# Altium schematic sheet 01.W5100S.SchDoc
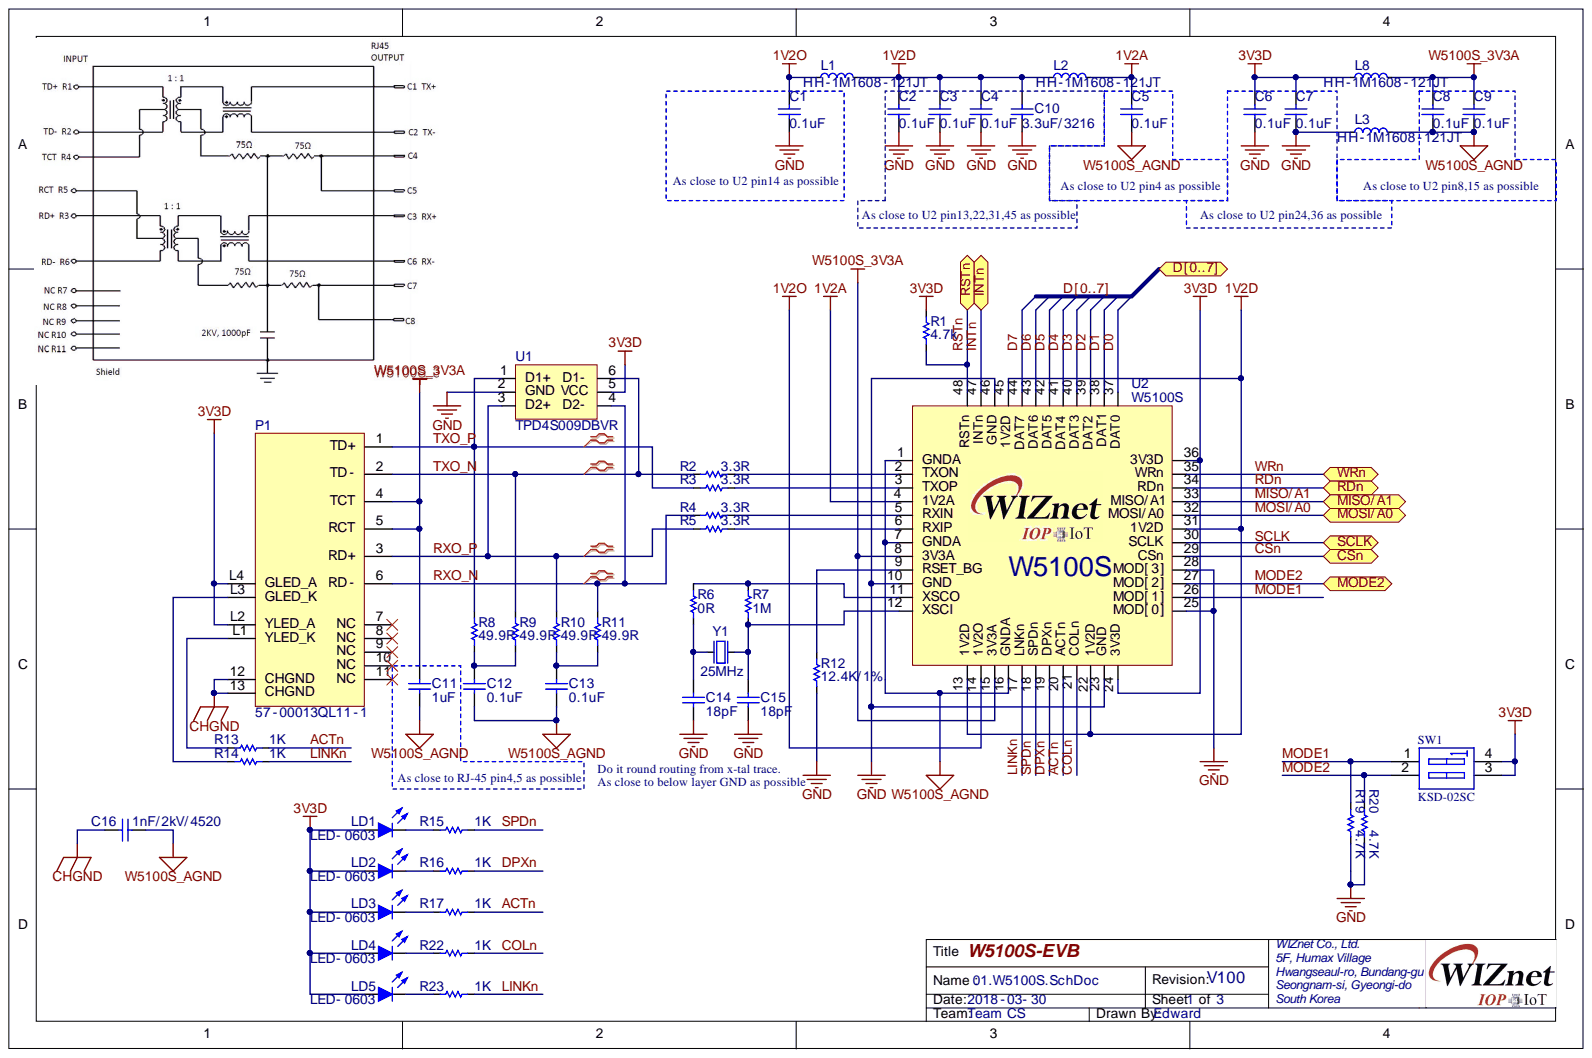
[diagram p0: 01.W5100S.SchDoc]

## Parts
C1  0.1uF  [CAP-0603]
C2  0.1uF  [CAP-0603]
C3  0.1uF  [CAP-0603]
C4  0.1uF  [CAP-0603]
C5  0.1uF  [CAP-0603]
C6  0.1uF  [CAP-0603]
C7  0.1uF  [CAP-0603]
C8  0.1uF  [CAP-0603]
C9  0.1uF  [CAP-0603]
C10  3.3uF/3216  [CAP-1206]
C11  1uF  [CAP-0603]
C12  0.1uF  [CAP-0603]
C13  0.1uF  [CAP-0603]
C14  18pF  [CAP-0603]
C15  18pF  [CAP-0603]
C16  1nF/2kV/4520  [CAP-1808]
L1  HH-1M1608-121JT  [IND-0603]
L2  HH-1M1608-121JT  [IND-0603]
L3  HH-1M1608-121JT  [IND-0603]
L8  HH-1M1608-121JT  [IND-0603]
LD1  LED-0603  [LED-0603-RED]
LD2  LED-0603  [LED-0603-RED]
LD3  LED-0603  [LED-0603-RED]
LD4  LED-0603  [LED-0603-RED]
LD5  LED-0603  [LED-0603-RED]
P1  57-00013QL11-1  [RJ45-57-00013QL11-1]
R1  4.7k  [RES-0603]
R2  3.3R  [RES-0603]
R3  3.3R  [RES-0603]
R4  3.3R  [RES-0603]
R5  3.3R  [RES-0603]
R6  0R  [RES-0603]
R7  1M  [RES-0603]
R8  49.9R  [RES-0603]
R9  49.9R  [RES-0603]
R10  49.9R  [RES-0603]
R11  49.9R  [RES-0603]
R12  12.4K/1%  [RES-0603]
R13  1K  [RES-0603]
R14  1K  [RES-0603]
R15  1K  [RES-0603]
R16  1K  [RES-0603]
R17  1K  [RES-0603]
R19  4.7K  [RES-0603]
R20  4.7K  [RES-0603]
R22  1K  [RES-0603]
R23  1K  [RES-0603]
SW1  KSD-02SC  [KSD-02SC]
U1  TPD4S009DBVR  [SOT-23-6L]
U2  W5100S  [LQFP48(0.5/9.0)]
Y1  25MHz  [OSC-82J0626]

## Ports
CSN, D0, D1, D2, D3, D4, D5, D6, D7, D[0..7], INTN, MISO/A1, MODE2, MOSI/A0, RDN, RSTN, SCLK, WRN

## Nets
1V2A: C5.2 L2.2 U2.4(1V2A)
1V2D: C2.2 C3.2 C4.2 C10.2 L1.2 L2.1 U2.13(1V2D) U2.22(1V2D) U2.31(1V2D) U2.45(1V2D)
1V2O: C1.2 L1.1 U2.14(1V2O)
3V3D: C6.2 C7.2 L8.1 LD1.1 LD2.1 LD3.1 LD4.1 LD5.1 P1.L2(YLED_A) P1.L4(GLED_A) R1.2 SW1.3 SW1.4 U1.5(VCC) U2.24(3V3D) U2.36(3V3D)
CHGND: C16.1 P1.12(CHGND) P1.13(CHGND)
GND: C1.1 C2.1 C3.1 C4.1 C6.1 C7.1 C10.1 C14.1 C15.1 L3.1 R12.1 R19.1 R20.1 U1.2(GND) U2.10(GND) U2.23(GND) U2.25(MOD[_0_]) U2.28(MOD[_3_]) U2.46(GND) Y1.2 Y1.4
W5100S_3V3A: C8.2 C9.2 C11.2 L8.2 P1.4(TCT) P1.5(RCT) U2.8(3V3A) U2.15(3V3A)
W5100S_AGND: C5.1 C8.1 C9.1 C11.1 C12.1 C13.1 C16.2 L3.2 U2.1(GNDA) U2.7(GNDA) U2.16(GNDA)
ACTN: R13.1 R17.1 U2.20(ACTn)
COLN: R22.1 U2.21(COLn)
CSN: U2.29(CSn) ; port CSN
D0: U2.37(DAT0) ; port D[0..7]  [also labelled D]
D1: U2.38(DAT1) ; port D[0..7]  [also labelled D]
D2: U2.39(DAT2) ; port D[0..7]  [also labelled D]
D3: U2.40(DAT3) ; port D[0..7]  [also labelled D]
D4: U2.41(DAT4) ; port D[0..7]  [also labelled D]
D5: U2.42(DAT5) ; port D[0..7]  [also labelled D]
D6: U2.43(DAT6) ; port D[0..7]  [also labelled D]
D7: U2.44(DAT7) ; port D[0..7]  [also labelled D]
DPXN: R16.1 U2.19(DPXn)
INTN: U2.47(INTn) ; port INTN
LINKN: R14.1 R23.1 U2.17(LNKn)
MISO/A1: U2.33(MISO/_A1) ; port MISO/A1
MODE1: R19.2 SW1.1 U2.26(MOD[_1_])
MODE2: R20.2 SW1.2 U2.27(MOD[_2_]) ; port MODE2
MOSI/A0: U2.32(MOSI/_A0) ; port MOSI/A0
RDN: U2.34(RDn) ; port RDN
RSTN: R1.1 U2.48(RSTn) ; port RSTN
RXO_N: P1.6(RD_-) R4.2 R11.2 U1.4(D2-)
RXO_P: P1.3(RD+) R5.2 R10.2 U1.3(D2+)
SCLK: U2.30(SCLK) ; port SCLK
SPDN: R15.1 U2.18(SPDn)
TXO_N: P1.2(TD_-) R2.2 R9.2 U1.6(D1-)
TXO_P: P1.1(TD+) R3.2 R8.2 U1.1(D1+)
WRN: U2.35(WRn) ; port WRN
NetC12_2: C12.2 R8.1 R9.1
NetC13_2: C13.2 R10.1 R11.1
NetC14_2: C14.2 R6.1 Y1.1
NetC15_2: C15.2 R7.1 U2.12(XSCI) Y1.3
NetLD1_2: LD1.2 R15.2
NetLD2_2: LD2.2 R16.2
NetLD3_2: LD3.2 R17.2
NetLD4_2: LD4.2 R22.2
NetLD5_2: LD5.2 R23.2
NetP1_L1: P1.L1(YLED_K) R13.2
NetP1_L3: P1.L3(GLED_K) R14.2
NetR2_1: R2.1 U2.2(TXON)
NetR3_1: R3.1 U2.3(TXOP)
NetR4_1: R4.1 U2.5(RXIN)
NetR5_1: R5.1 U2.6(RXIP)
NetR6_2: R6.2 R7.2 U2.11(XSCO)
NetR12_2: R12.2 U2.9(RSET_BG)

Not connected: P1 7(NC) 8(NC) 9(NC) 10(NC) 11(NC)

## Library data of the parts
C1-C9 C11-C15 = CAP-0603: Ceramic CAP 0603(1608); Component Kind: Standard; Library Name: Scott_lib.IntLib
C10 = CAP-1206: Ceramic CAP 1206(3216); Component Kind: Standard; Library Name: Scott_lib.IntLib
C16 = CAP-1808: Ceramic CAP 1808(4520); Component Kind: Standard; Library Name: Scott_lib.IntLib
L1-L3 L8 = IND-0603: Inductor 0603(1608); Component Kind: Standard; Library Name: Scott_lib.IntLib
R1-R17 R19 R20 R22 R23 = RES-0603: RES0603; Component Kind: Standard; Library Name: Scott_lib.IntLib
SW1 = KSD-02SC: DIP Switch, 2 Position, SPST; Component Kind: Standard; LatestRevisionDate: 17-Jul-2002; LatestRevisionNote: Re-released for DXP Platform.; PackageReference: DIP-4; Published: 24-Mar-1999; Library Name: Edward.IntLib; Publisher: Altium Limited
U1 = TPD4S009DCKR: 4-Channel ESD Solution for High-Speed Differential Interface; Component Kind: Standard; Library Name: Scott_lib.IntLib
U2 = W5100S: WIZnet Hardwired TCP/IP Chip; Component Kind: Standard; Library Name: Scott_lib.IntLib
Y1 = OSC-82J0626-25MHz: X-tal 25MHz,3.2x2.5 SMD, CL=18pF; Component Kind: Standard; Library Name: Scott_lib.IntLib
LD1-LD5 = LED-0603: Component Kind: Standard; Description: LED-0603; Library Name: Scott_lib.IntLib
P1 = 57-00013QL11-1: Component Kind: Standard; Library Name: Scott_lib.IntLib
